# Altium schematic sheet DB10012_ControlTopLevel.SchDoc
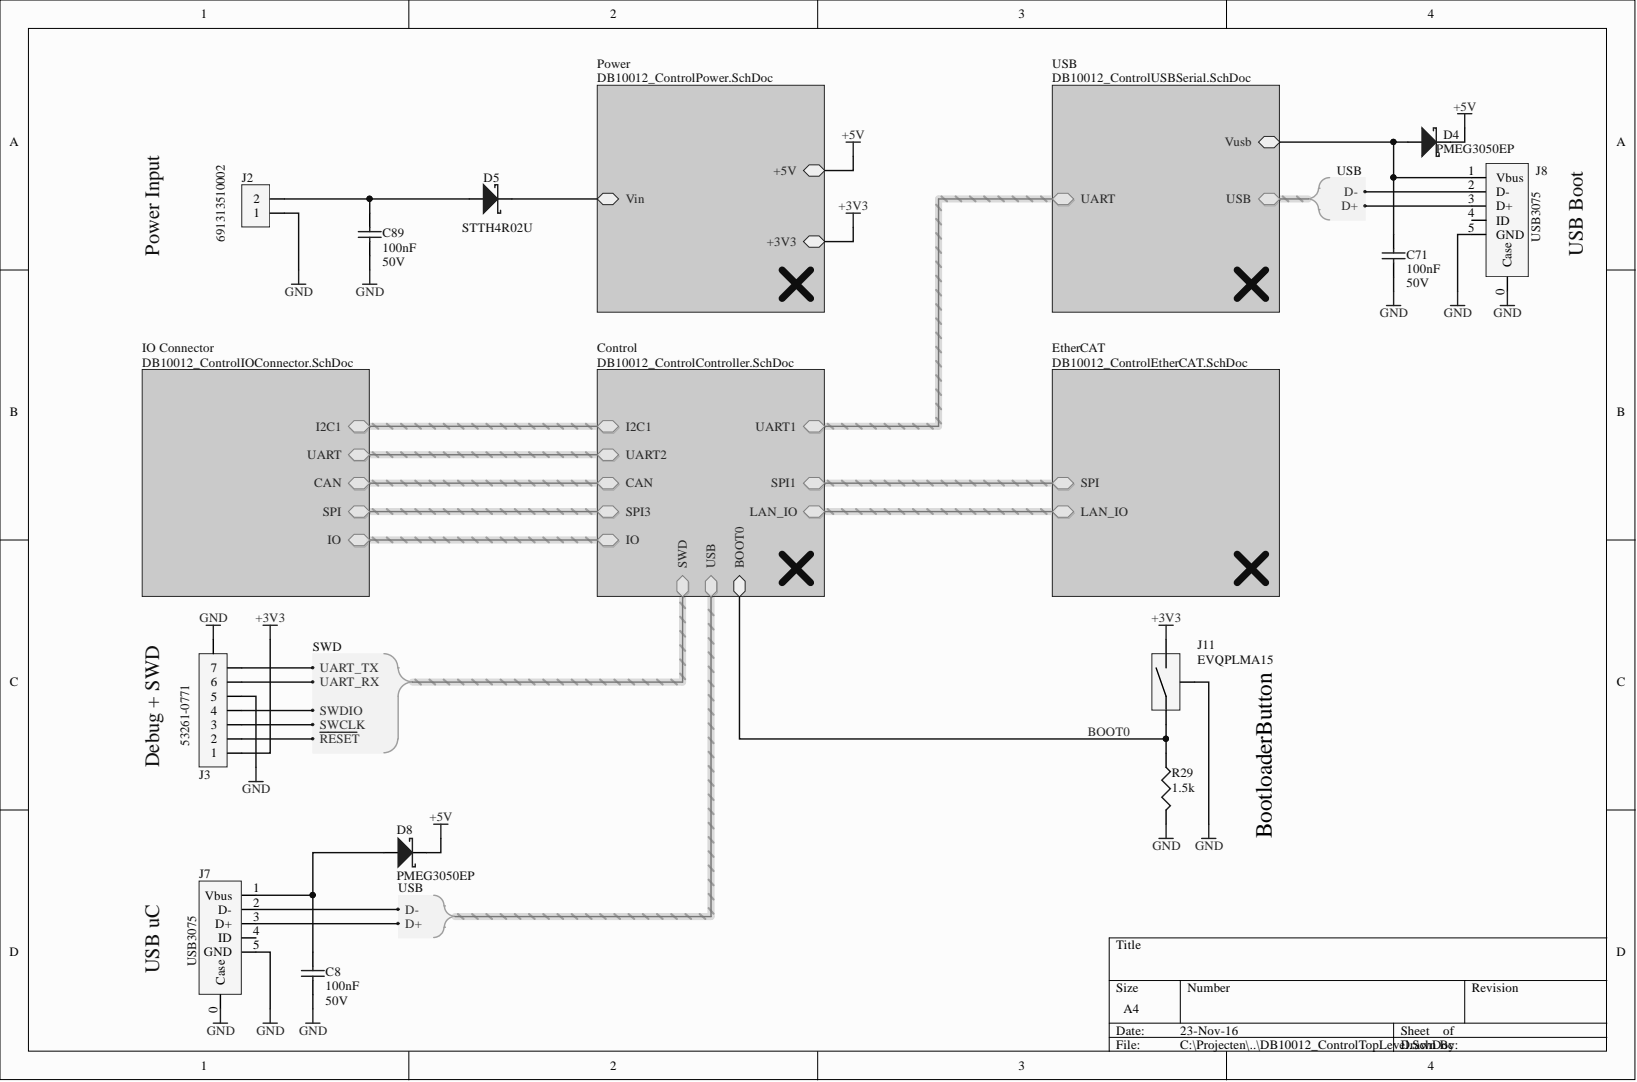
[diagram p0: DB10012_ControlTopLevel.SchDoc]

## Parts
C8  100nF  Voltage Rating: 50V  [C-0805]
C71  100nF  Voltage Rating: 50V  [C-0805]
C89  100nF  Voltage Rating: 50V  [C-0805]
D4  PMEG3050EP  [SOD128]
D5  STTH4R02U  [CASE 403A-03]
D8  PMEG3050EP  [SOD128]
J2  691313510002  [691313510002]
J3  53261-0771  [53261-0771]
J7  USB3075  [USB3075 - NOSLOT]
J8  USB3075  [USB3075 - NOSLOT]
J11  EVQPLMA15  [EVQPLM]
R29  1.5k  [0402]

## Nets
+3V3: J3.1 J11.2
+5V: D4.2 D8.2
GND: C8.1 C71.1 C89.1 J2.1 J3.0 J3.5 J7.0(Case) J7.5(GND) J8.0(Case) J8.5(GND) J11.0 R29.1
BOOT0: J11.1 R29.2
NetC8_2: C8.2 D8.1 J7.1(Vbus)
NetC71_2: C71.2 D4.1 J8.1(Vbus)
NetC89_2: C89.2 D5.1 J2.2
NetD5_2: D5.2
NetJ3_2: J3.2
NetJ3_3: J3.3
NetJ3_4: J3.4
NetJ3_6: J3.6
NetJ3_7: J3.7
NetJ7_2: J7.2(D-)
NetJ7_3: J7.3(D+)
NetJ7_4: J7.4(ID)
NetJ8_2: J8.2(D-)
NetJ8_3: J8.3(D+)
NetJ8_4: J8.4(ID)
CAN.RX:
CAN.TX:
I2C1.INT:
I2C1.SCL:
I2C1.SDA:
IO.GPIO0:
IO.GPIO1:
IO.GPIO2:
LAN_IO.IRQ:
LAN_IO.SYNC0:
LAN_IO.SYNC1:
N00016:
N00017:
N00018:
N00019:
N00020:
N00021:
N00022:
N00023:
SPI1.~{CS}:
SPI1.MISO:
SPI1.MOSI:
SPI1.SCK:
SPI3.~{CS}:
SPI3.MISO:
SPI3.MOSI:
SPI3.SCK:
UART1.RX:
UART1.TX:
UART2.RX:
UART2.TX:

## Library data of the parts
C8 C71 C89 = 100nF/0805/CER/50V: Capacitor, 100nF, 50V,  X7R; Brand: KEMET; Brand Number: C0805C104K5RACTU; Capacitance Tolerance: ± 10%; Capacitor Case Style: 0805; Capacitance: 100nF; Component Kind: Standard; Dielectric Characteristic: X7R; Library Name: AR_Capacitors_Ceramic.IntLib; Order Code Supplier: 1414664; Price: 0,022; Supplier: Farnell; Temperature Range: -55°C to +125°C
D4 D8 = PMEG3050EP: Diode Schottky, Vrrm: 30V, If: 3A, Vf: 0.36V; Brand: NXP; Case Style: SOD-128; Forward Current: 5A; Brand Number: PMEG3050EP; Component Kind: Standard; Forward Voltage: 0.36V; Library Name: AR_Diodes.IntLib; Order Code Supplier: 1829197; Price: 0,25; Supplier: Farnell; Temperature Range: -55°C to +150°C; Vrrm: 30V
D5 = STTH4R02U: Diode Schottky, Vrrm: 200V, If: 4A, Vf: 1,05V; Brand: STMICROELECTRONICS; Case Style: SMB; Forward Current: 3A; Forward Voltage: 1,05V; Brand Number: STTH4R02U; Component Kind: Standard; Library Name: AR_Diodes.IntLib; Order Code Supplier: 1295277; Price: 1,09; Supplier: Farnell; Temperature Range: -65°C to +150°C; Vrrm: 200V
J2 = 691313510002: WR-TBL 313 Series, 2 Contacts, Header, 5.08 mm; Brand: Wurth; Pitch: 5.08mm; Brand Number: 691313510002; Case Style: WR-TBL 313 Series; Component Kind: Standard; Library Name: AR_Connectors.IntLib; Order Code Supplier: 1641993; Price: 0,343; Supplier: Farnell
J3 = 53261-0771: PicoBlade 53261 Series, 7Pin, Right Angle; Brand: Molex; Pitch: 1,25mm; Brand Number: 53261-0771; Case Style: 53261-0771; Component Kind: Standard; Library Name: AR_Connectors.IntLib; Order Code Supplier: 1125361; Price: 1,80; Supplier: Farnell
J7 J8 = USB3075: USB 2.0 MICRO B, RCPT, 5POS, SMT; Brand: Global Connector Technology; Brand Number: USB3075-30-A; Case Style: Micro B SMT; Pitch: 0.8mm; Component Kind: Standard; Library Name: AR_Connectors.IntLib; Order Code Supplier: 2443116; Price: 0,313; Supplier: Farnell
J11 = EVQPLMA15: SWITCH, TACT, 4.9MM, SMD, 2.6N; Brand: PANASONIC ELECTRONIC COMPONENTS; Brand Number: EVQPLMA15; Component Kind: Standard; Library Name: AR_Misc.IntLib; Order Code Supplier: 2079484; Price: 0,169; Supplier: Farnell
R29 = 1.5k/0402/0063W/50V: Resistor, 1.5k, 0.1%, 0.0625W, 50V, 100PPM, 0402; Brand: VISHAY DRALORIC; Brand Number: CRCW04021K50FKED; Power Rating: 0.063W; Case Style: 0402; Component Kind: Standard; Library Name: AR_Resistors_SMD.IntLib; Order Code Supplier: 2121624; Price: 0,0054; Resistance: 1.5k; Supplier: Farnell; Temperature Coefficient: ± 100PPM; Temperature Range: -55°C to +125°C; Voltage Rating: 50V
